# Altium schematic sheet Sheet5.SchDoc
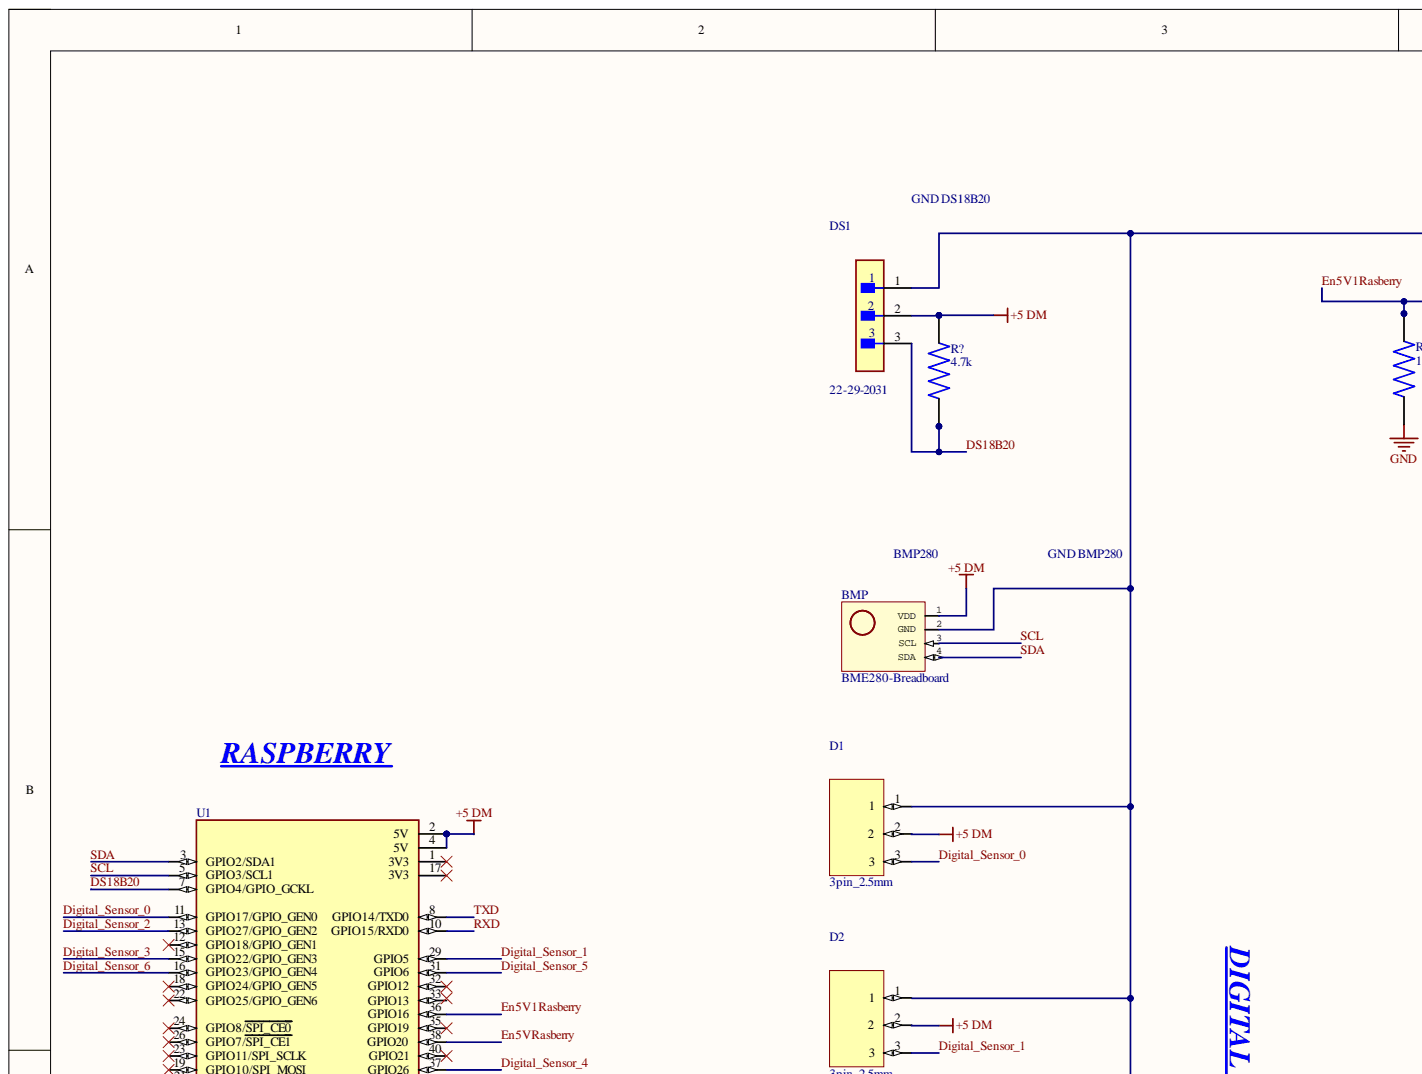
[diagram p0: Sheet5.SchDoc, top left]
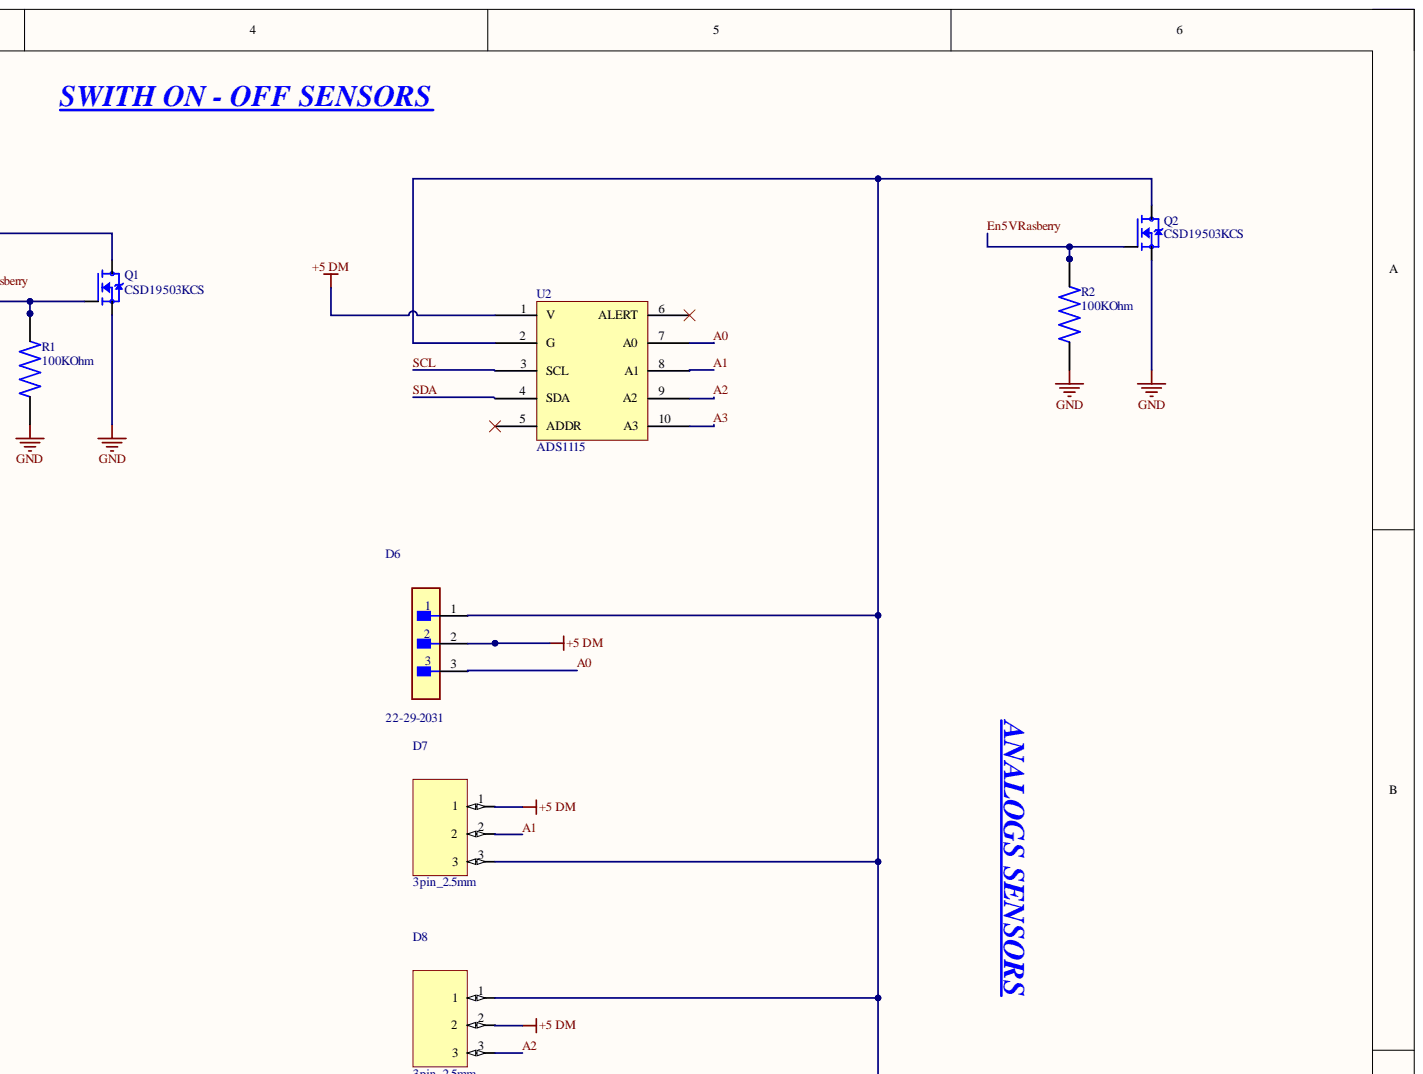
[diagram p1: Sheet5.SchDoc, top right]
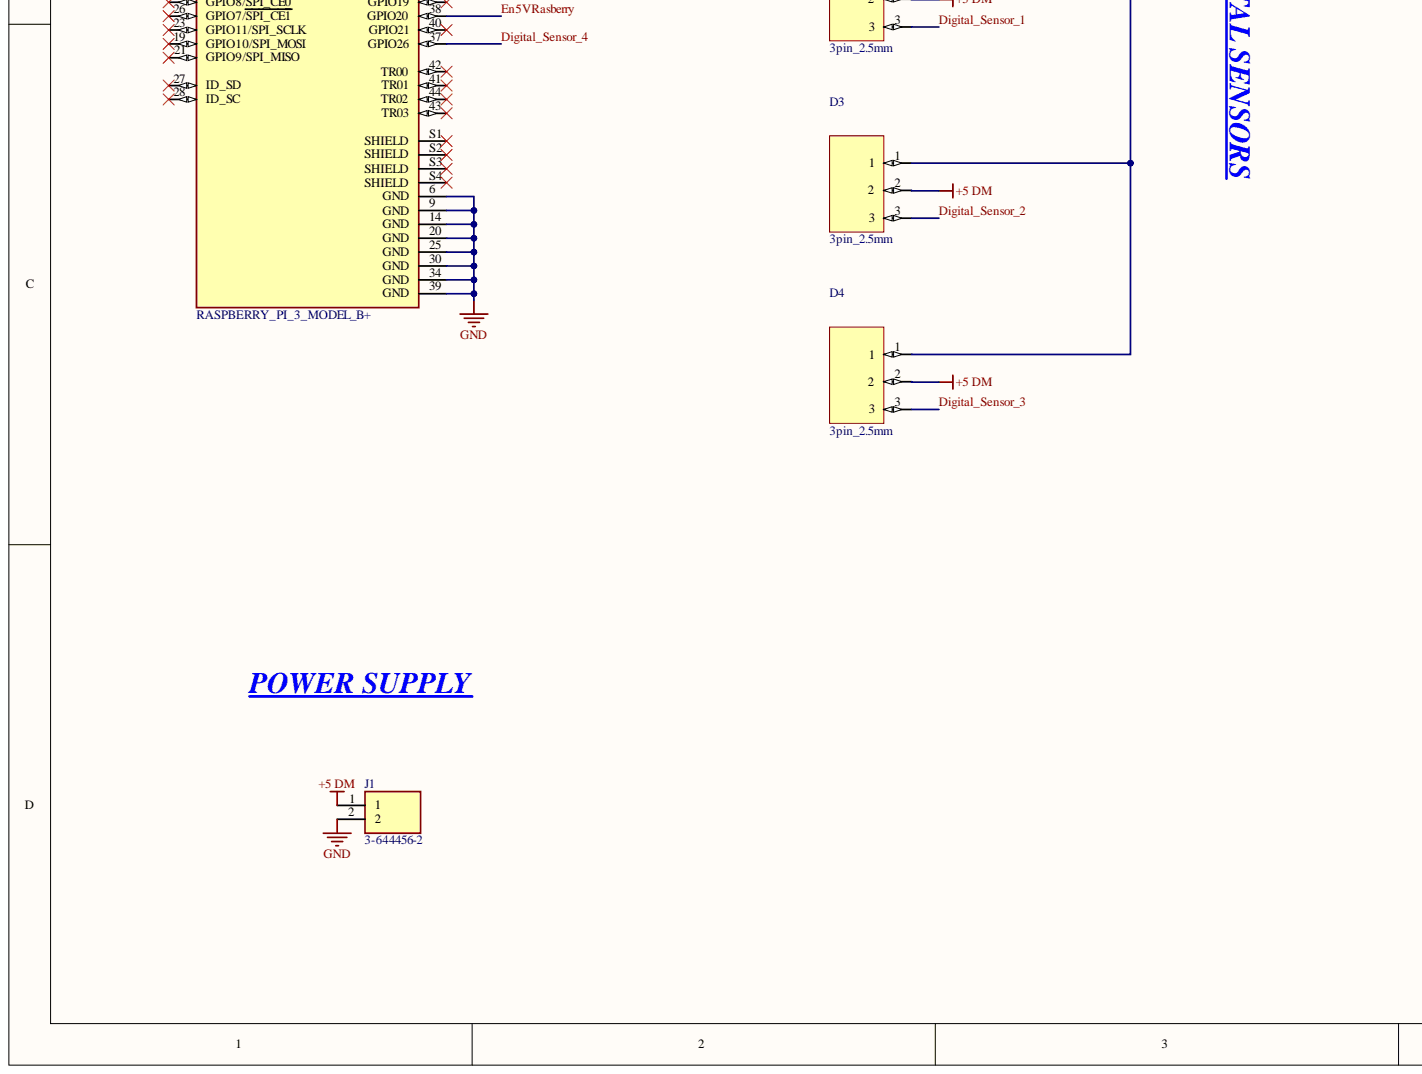
[diagram p2: Sheet5.SchDoc, bottom left]
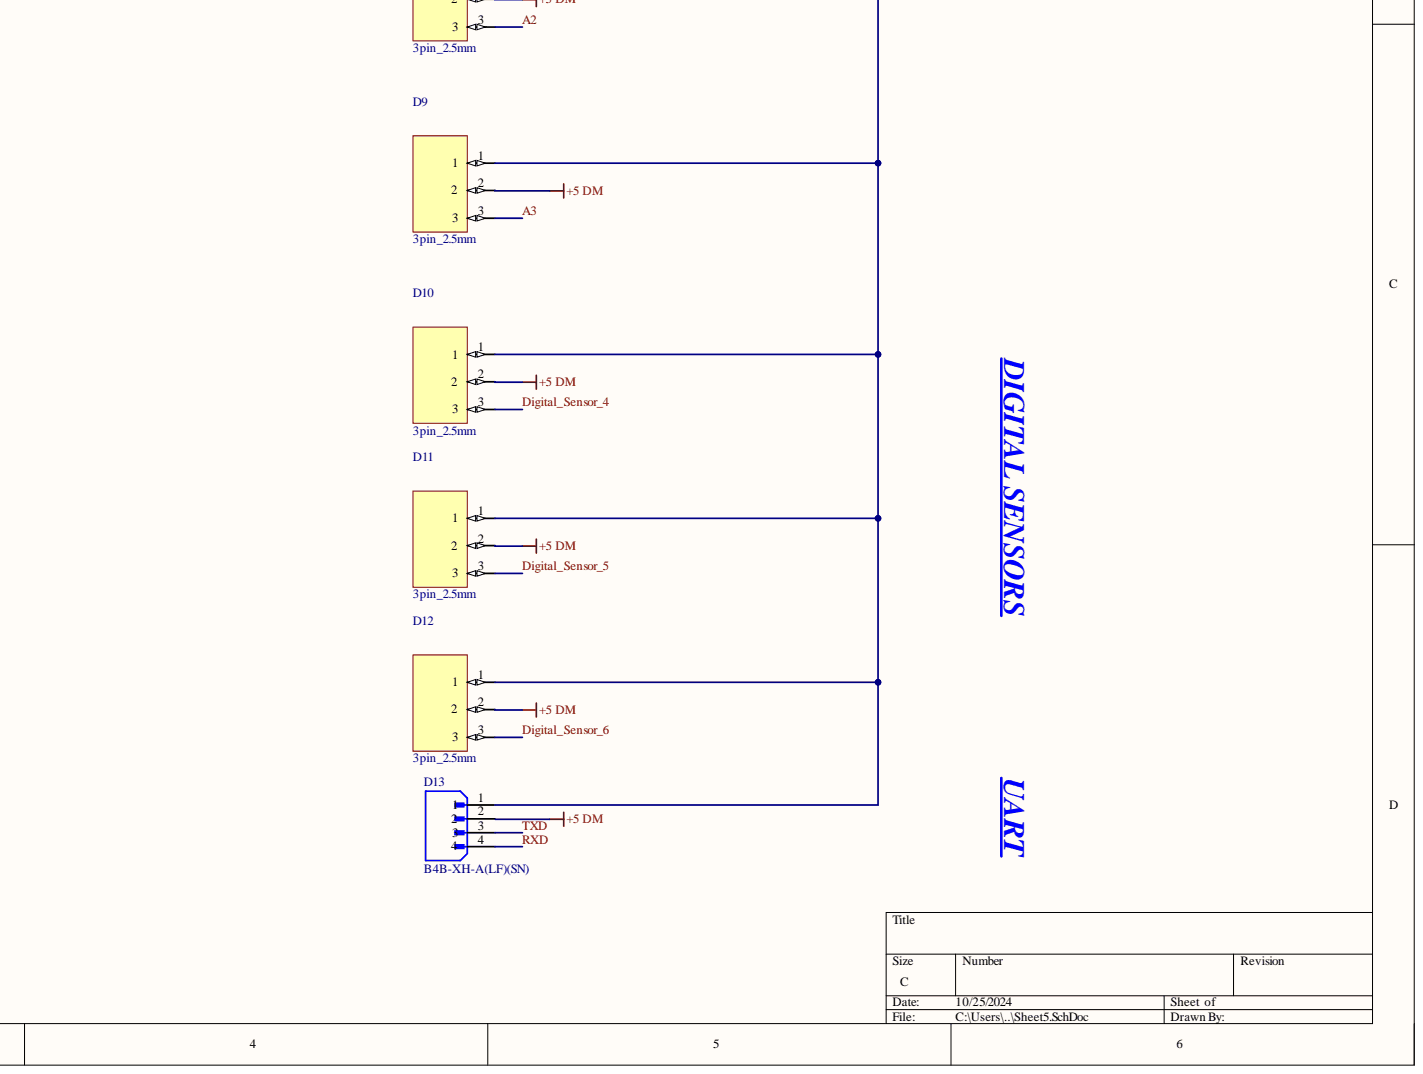
[diagram p3: Sheet5.SchDoc, bottom right]

## Parts
BMP  BME280-Breadboard  [GY-BME280]
D1  3pin_2.5mm  [HEADER_3]
D2  3pin_2.5mm  [HEADER_3]
D3  3pin_2.5mm  [HEADER_3]
D4  3pin_2.5mm  [HEADER_3]
D6  22-29-2031  [FP-22-29-2031-MFG]
D7  3pin_2.5mm  [HEADER_3]
D8  3pin_2.5mm  [HEADER_3]
D9  3pin_2.5mm  [HEADER_3]
D10  3pin_2.5mm  [HEADER_3]
D11  3pin_2.5mm  [HEADER_3]
D12  3pin_2.5mm  [HEADER_3]
D13  B4B-XH-A(LF)(SN)  [JST_B4B-XH-A(LF)(SN)]
DS1  22-29-2031  [FP-22-29-2031-MFG]
J1  3-644456-2  [TE_3-644456-2]
Q1  CSD19503KCS  [TO254P1036X470X1965-3]
Q2  CSD19503KCS  [TO254P1036X470X1965-3]
R1  100KOhm  [RESAD1100W55L680D260]
R2  100KOhm  [RESAD1100W55L680D260]
R?  4.7k  [RESAD1100W55L680D260]
U1  RASPBERRY_PI_3_MODEL_B+  [MODULE_RASPBERRY_PI_3_MODEL_B+]
U2  ADS1115  [PCBComponent_1]

## Nets
+5 DM: BMP.1(VDD) D1.2 D2.2 D3.2 D4.2 D6.2 D7.1 D8.2 D9.2 D10.2 D11.2 D12.2 DS1.2 J1.1 R?.2 U1.2(5V) U1.4(5V) U2.1(V)
GND: J1.2 Q1.3 Q2.3 R1.1 R2.1 U1.6(GND) U1.9(GND) U1.14(GND) U1.20(GND) U1.25(GND) U1.30(GND) U1.34(GND) U1.39(GND)
A0: D6.3 U2.7(A0)
A1: D7.2 U2.8(A1)
A2: D8.3 U2.9(A2)
A3: D9.3 U2.10(A3)
Digital_Sensor_0: D1.3 U1.11(GPIO17/GPIO_GEN0)
Digital_Sensor_1: D2.3 U1.29(GPIO5)
Digital_Sensor_2: D3.3 U1.13(GPIO27/GPIO_GEN2)
Digital_Sensor_3: D4.3 U1.15(GPIO22/GPIO_GEN3)
Digital_Sensor_4: D10.3 U1.37(GPIO26)
Digital_Sensor_5: D11.3 U1.31(GPIO6)
Digital_Sensor_6: D12.3 U1.16(GPIO23/GPIO_GEN4)
DS18B20: DS1.3 R?.1 U1.7(GPIO4/GPIO_GCKL)
En5V1Rasberry: Q1.1 R1.2 U1.36(GPIO16)
En5VRasberry: Q2.1 R2.2 U1.38(GPIO20)
RXD: D13.4 U1.10(GPIO15/RXD0)
SCL: BMP.3(SCL) U1.5(GPIO3/SCL1) U2.3(SCL)
SDA: BMP.4(SDA) U1.3(GPIO2/SDA1) U2.4(SDA)
TXD: D13.3 U1.8(GPIO14/TXD0)
NetBMP_2: BMP.2(GND) D1.1 D3.1 D4.1 DS1.1 Q1.2
NetD6_1: D6.1 D7.3 D8.1 D9.1 D10.1 D11.1 D12.1 D13.1 Q2.2 U2.2(G)

Not connected: D2 1; D13 2; U1 1(3V3) 12(GPIO18/GPIO_GEN1) 17(3V3) 18(GPIO24/GPIO_GEN5) 19(GPIO10/SPI_MOSI) 21(GPIO9/SPI_MISO) 22(GPIO25/GPIO_GEN6) 23(GPIO11/SPI_SCLK) 24(GPIO8/SPI_CE0) 26(GPIO7/SPI_CE1) 27(ID_SD) 28(ID_SC) 32(GPIO12) 33(GPIO13) 35(GPIO19) 40(GPIO21) 41(TR01) 42(TR00) 43(TR03) 44(TR02) S1(SHIELD) S2(SHIELD) S3(SHIELD) S4(SHIELD); U2 5(ADDR) 6(ALERT)

## Library data of the parts
BMP = BME280-Breadboard: Manufacturer: Bosch; MFR: GY-BME280 - 5V; CheckBy: Manuel; Component Kind: Standard; Library Name: ENCORE.SCHLIB
D6 DS1 = CMP-04776-000327-1: CONN HEADER VERT 3POS 2.54MM; Color: Natural; Connector Type: Male Pin; Contact Resistance: 10mR; Current Rating: 4A; Gender: Male; Number of Contacts: 3; Voltage Rating: 250V; Component Kind: Standard; Contact Material: Brass; Contact Mating Length: 0.295inch; Contact Plating: Gold; Contact Type: Male Pin; Depth: 5.8mm; Fastening Type: Friction; Housing Color: White; Housing Material: Nylon; Lead Pitch: 2.54mm; Length: 7.62mm; Library Name: Altium Content Vault; Max Operating Temperature: 75°C; Min Operating Temperature: 0°C; Mount: Through Hole; Number of Positions: 3; Number of Rows: 1; Orientation: Straight; Packaging: Bulk; Pitch: 2.54mm; Radiation Hardening: No; RoHS Compliant: Yes; Series: KK® 6410; Termination: Solder; Working Voltage: 250V
D13 = B4B-XH-A(LF)(SN): Connector Header Through Hole 4 position 0.098 (2.50mm); MF: JST Sales; Availability: In Stock; Check_prices: https://www.snapeda.com/parts/B4B-XH-A(LF)(SN)/JST+Sales+America+Inc./view-part/?ref=eda; Component Kind: Standard; Library Name: B4B-XH-A(LF)(SN).SchLib; MP: B4B-XH-A(LF)(SN); Package: None; Price: None; Purchase-URL: https://pricing.snapeda.com/search/part/B4B-XH-A(LF)(SN)/?ref=eda; SnapEDA_Link: https://www.snapeda.com/parts/B4B-XH-A(LF)(SN)/JST+Sales+America+Inc./view-part/?ref=snap
J1 = 3-644456-2: Connector Unshrouded Header HDR 2 Position 2.54mm Solder Straight Thru-Hole; Centerline_Pitch: 2.54 mm[.1 in]; MF: TE Connectivity; Number_of_Positions: 2; Component Kind: Standard; Digi-Key_Part_Number: A31112-ND; Digi-Key_Purchase_URL: https://www.digikey.com/product-detail/en/te-connectivity-amp-connectors/3-644456-2/A31112-ND/698344?utm_source=snapeda&utm_medium=aggregator&utm_campaign=symbol; EU_RoHS_Compliance: Compliant; Library Name: Header_2_pin.SchLib; MP: 3-644456-2; Package: None; Product_Type: Connector; SnapEDA_Link: https://www.snapeda.com/parts/3-644456-2/TE%20Connectivity%20AMP%20Connectors/view-part/520887/?ref=snap; TE_purchase_URL: https://www.te.com/usa-en/product-3-644456-2.html?te_bu=Cor&te_type=disp&te_campaign=seda_glo_cor-seda-global-disp-prtnr-fy19-seda-model-bom-cta_sma-317_1&elqCampaignId=32493
Q1 Q2 = CSD19503KCS: 80-V, N channel NexFETâ„¢ power MOSFET, single TO-220, 9.2 mOhm; MF: Texas Instruments; Availability: In Stock; Check_prices: https://www.snapeda.com/parts/CSD19503KCS/Texas+Instruments/view-part/?ref=eda; Component Kind: Standard; Library Name: CSD19503KCS.SchLib; MP: CSD19503KCS; Package: TO-220-3 Texas Instruments; Price: None; Purchase-URL: https://pricing.snapeda.com/search/part/CSD19503KCS/?ref=eda; SnapEDA_Link: https://www.snapeda.com/parts/CSD19503KCS/Texas+Instruments/view-part/?ref=snap
R1 R2 R? = MFR-25FBF52-10K: 10.2 kOhms Â±1% 0.25W, 1/4W Through Hole Resistor Axial - Metal Film; MF: Yageo; Availability: In Stock; Check_prices: https://www.snapeda.com/parts/MFR-25FBF52-10K/Yageo/view-part/?ref=eda; Component Kind: Standard; Library Name: MFR-25FBF52-10K.SchLib; MP: MFR-25FBF52-10K; Package: Axial Yageo; Price: None; Purchase-URL: https://pricing.snapeda.com/search/part/MFR-25FBF52-10K/?ref=eda; SnapEDA_Link: https://www.snapeda.com/parts/MFR-25FBF52-10K/Yageo/view-part/?ref=snap
U1 = RASPBERRY_PI_3_MODEL_B+: Case Plastic, ABS Black Hand Held, Split Sides 3.520 L x 2.740 W (89.41mm x 69.60mm) X 0.980 (24.89mm); MF: Raspberry Pi; MP: RASPBERRY PI 3 MODEL B+; Availability: In Stock; Check_prices: https://www.snapeda.com/parts/RASPBERRY%20PI%203%20MODEL%20B+/Raspberry+Pi/view-part/?ref=eda; Component Kind: Standard; Library Name: RASPBERRY_PI_3_MODEL_B+.SchLib; Package: Raspberry Pi; Price: None; Purchase-URL: https://pricing.snapeda.com/search/part/RASPBERRY PI 3 MODEL B+/?ref=eda; SnapEDA_Link: https://www.snapeda.com/parts/RASPBERRY%20PI%203%20MODEL%20B+/Raspberry+Pi/view-part/?ref=snap
D1-D4 D7-D12 = 3pin_2.5mm: Component Kind: Standard; Library Name: 3PIN_2.5mm.SchLib
U2 = ADS1115: Component Kind: Standard; Library Name: GY-ADS1115.SchLib
